# Altium schematic sheet RearPlateMechanicalRev2b.SchDoc
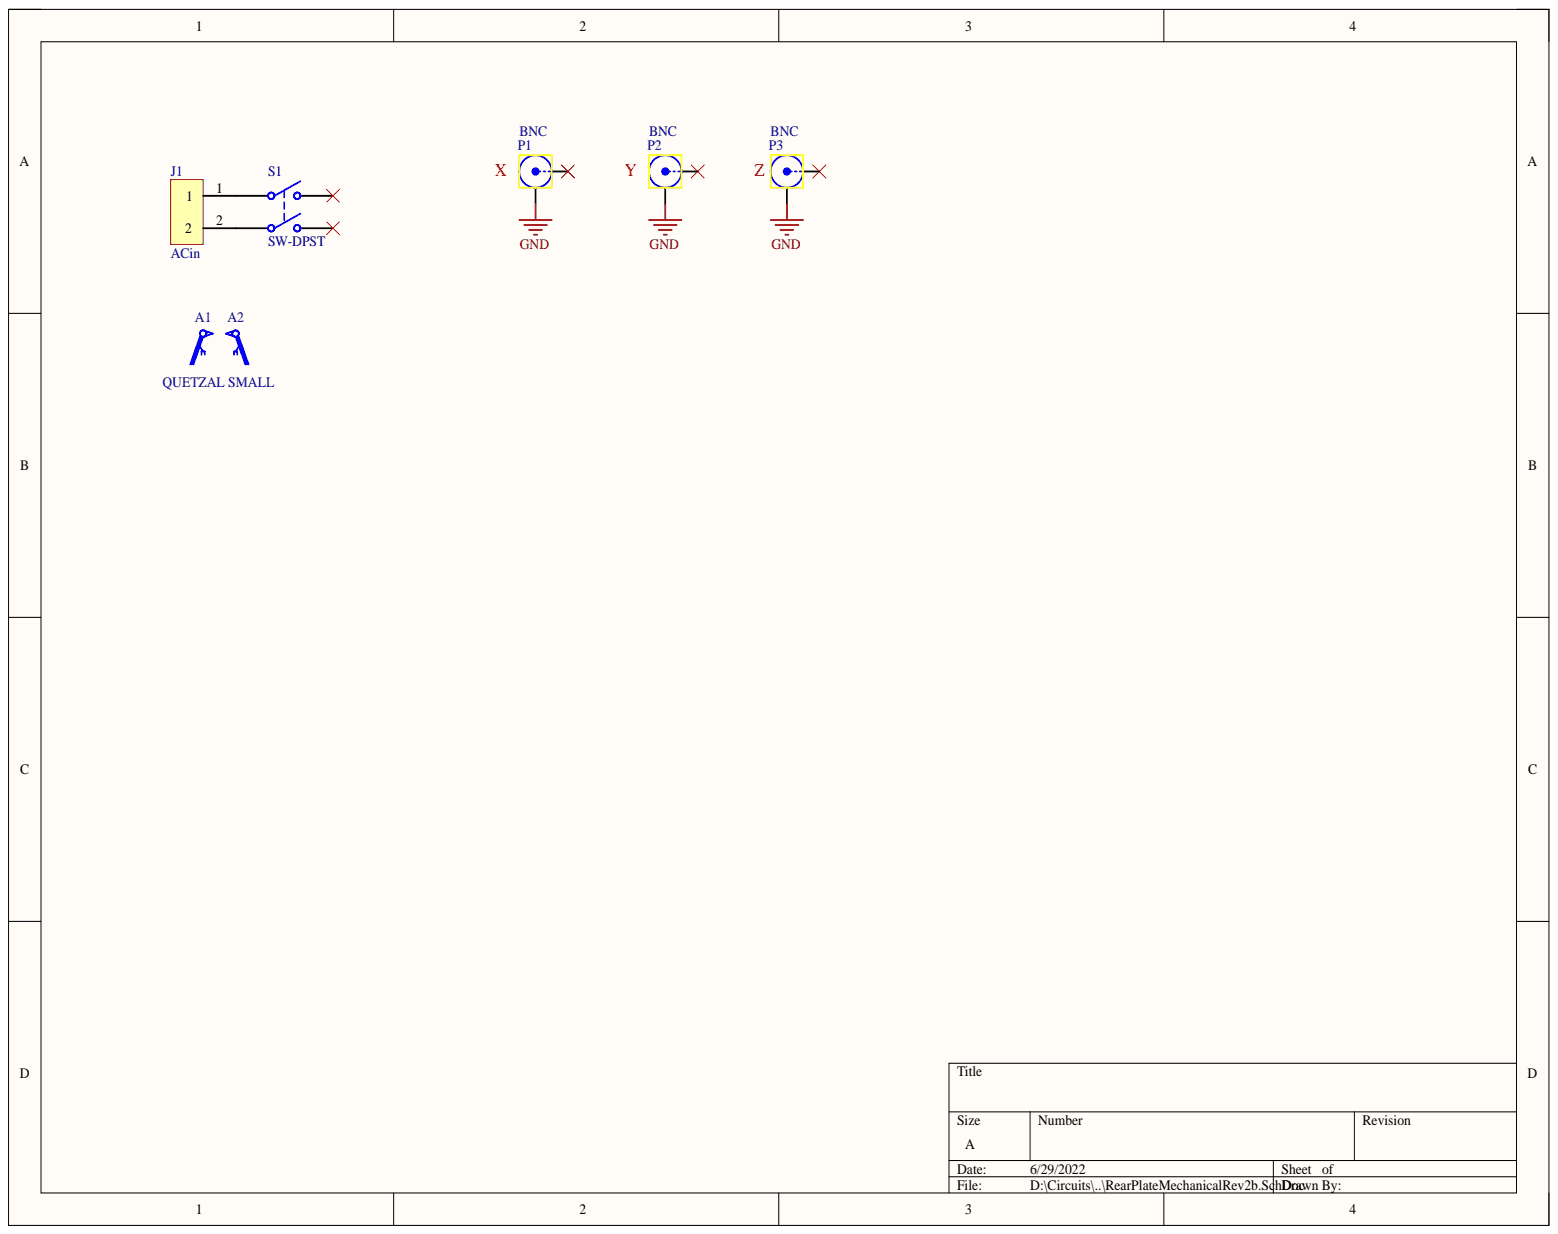
[diagram p0: RearPlateMechanicalRev2b.SchDoc]

## Parts
A1  QUETZAL SMALL  [QUETZAL LT]  (no BOM)
A2  QUETZAL SMALL  [R QUETZAL LT]  (no BOM)
J1  ACin  [5008]
P1  BNC  [BNC]
P2  BNC  [BNC]
P3  BNC  [BNC]
S1  SW-DPST  [CWSB]

## Nets
GND: P1.1 P2.1 P3.1
NetJ1_1: J1.1 S1.1
NetJ1_2: J1.2 S1.3

Not connected: P1 2; P2 2; P3 2; S1 2 4

## Library data of the parts
J1 = 2-Pin: PEM w/Filter, IEC, C8, 250VAC, 2.5A, Front Panel Mt-Screw, Solder Term, Stndrd, IP40, PC2; Manufacturer: Schurter; Manufacturer Part Number: 5008.0022; Approvals: cULus,ENEC; Connector Type: Receptacle; Filter Type: STANDARD; Frequency: 50Hz; Fuse: No; Gender: Male; Max Voltage Rating (AC): 250V; Number of Contacts: 2; Value: 5008; Case/Package: Module; Category: Connectors; Component Kind: Standard; ComponentLink1Description: https://datasheet.ciiva.com/709/eng-cd-640456-w1-709680.pdf?src-supplier=Digi-Key; ComponentLink1URL: https://datasheet.ciiva.com/709/eng-cd-640456-w1-709680.pdf?src-supplier=Digi-Key; ComponentLink2Description: https://datasheet.ciiva.com/3118/eng-ds-82056-mta-0608-3118497.pdf?src-supplier=Avnet; ComponentLink2URL: https://datasheet.ciiva.com/3118/eng-ds-82056-mta-0608-3118497.pdf?src-supplier=Avnet; ComponentLink3Description: https://datasheet.ciiva.com/16401/eng-ds-82056-mta-0608-16401289.pdf?src-supplier=Future+Electronics; ComponentLink3URL: https://datasheet.ciiva.com/16401/eng-ds-82056-mta-0608-16401289.pdf?src-supplier=Future+Electronics; ComponentLink4Description: https://datasheet.ciiva.com/25617/0900766b80027008-25617244.pdf?src-supplier=RSComponents; ComponentLink4URL: https://datasheet.ciiva.com/25617/0900766b80027008-25617244.pdf?src-supplier=RSComponents; ComponentLink5Description: https://datasheet.ciiva.com/14156/04384301-14156820.pdf?src-supplier=Distrelec; ComponentLink5URL: https://datasheet.ciiva.com/14156/04384301-14156820.pdf?src-supplier=Distrelec; ComponentLink6Description: https://datasheet.ciiva.com/11746/0900766b80eb7fdd-11746365.pdf?src-supplier=RSComponents; ComponentLink6URL: https://datasheet.ciiva.com/11746/0900766b80eb7fdd-11746365.pdf?src-supplier=RSComponents; ComponentLink7Description: https://datasheet.ciiva.com/7128/1866390-7128742.pdf?src-supplier=Newark; ComponentLink7URL: https://datasheet.ciiva.com/7128/1866390-7128742.pdf?src-supplier=Newark; ComponentLink8Description: https://datasheet.ciiva.com/16505/eng-ds-82056-mta-0608-16505419.pdf?src-supplier=Avnet+Asia; ComponentLink8URL: https://datasheet.ciiva.com/16505/eng-ds-82056-mta-0608-16505419.pdf?src-supplier=Avnet+Asia; ComponentLink9Description: https://datasheet.ciiva.com/18620/1713017-18620870.pdf?src-supplier=Digi-Key; ComponentLink9URL: https://datasheet.ciiva.com/18620/1713017-18620870.pdf?src-supplier=Digi-Key; ComponentLink10Description: https://datasheet.ciiva.com/16047/1989748-16047438.pdf?src-supplier=Onlinecomponents.com; ComponentLink10URL: https://datasheet.ciiva.com/16047/1989748-16047438.pdf?src-supplier=Onlinecomponents.com; ComponentLink11Description: https://datasheet.ciiva.com/11758/0900766b811d404e-11758587.pdf?src-supplier=RSComponents; ComponentLink11URL: https://datasheet.ciiva.com/11758/0900766b811d404e-11758587.pdf?src-supplier=RSComponents; ComponentLink12Description: https://datasheet.ciiva.com/6746/combiconspring-cagepcbterm-blocks-6746175.pdf?src-supplier=Digi-Key; ComponentLink12URL: https://datasheet.ciiva.com/6746/combiconspring-cagepcbterm-blocks-6746175.pdf?src-supplier=Digi-Key; ComponentLink13Description: https://datasheet.ciiva.com/14607/70055059-14607702.pdf?src-supplier=Allied+Electronics; ComponentLink13URL: https://datasheet.ciiva.com/14607/70055059-14607702.pdf?src-supplier=Allied+Electronics; ComponentLink14Description: https://datasheet.ciiva.com/16051/1988956-16051359.pdf?src-supplier=Onlinecomponents.com; ComponentLink14URL: https://datasheet.ciiva.com/16051/1988956-16051359.pdf?src-supplier=Onlinecomponents.com; ComponentLink15Description: https://datasheet.ciiva.com/26340/567541-da-01-de-printklemme-1988956-250-st-26340451.pdf?src-supplier=Conrad; ComponentLink15URL: https://datasheet.ciiva.com/26340/567541-da-01-de-printklemme-1988956-250-st-26340451.pdf?src-supplier=Conrad; ComponentLink16Description: https://datasheet.ciiva.com/824/combiconcompactseries-824224.pdf?src-supplier=Digi-Key; ComponentLink16URL: https://datasheet.ciiva.com/824/combiconcompactseries-824224.pdf?src-supplier=Digi-Key; ComponentLink17Description: https://datasheet.ciiva.com/14609/70055171-14609100.pdf?src-supplier=Allied+Electronics; ComponentLink17URL: https://datasheet.ciiva.com/14609/70055171-14609100.pdf?src-supplier=Allied+Electronics; ComponentLink18Description: https://datasheet.ciiva.com/30494/0900766b812440a5-30494169.pdf?src-supplier=RSComponents; ComponentLink18URL: https://datasheet.ciiva.com/30494/0900766b812440a5-30494169.pdf?src-supplier=RSComponents; ComponentLink19Description: https://datasheet.ciiva.com/1021/typ-5008-1021352.pdf?src-supplier=Digi-Key; ComponentLink19URL: https://datasheet.ciiva.com/1021/typ-5008-1021352.pdf?src-supplier=Digi-Key; ComponentLink20Description: https://datasheet.ciiva.com/30043/typ-5008-30043239.pdf?src-supplier=Avnet; ComponentLink20URL: https://datasheet.ciiva.com/30043/typ-5008-30043239.pdf?src-supplier=Avnet; ComponentLink21Description: https://datasheet.ciiva.com/14571/70080403-14571658.pdf?src-supplier=Allied+Electronics; ComponentLink21URL: https://datasheet.ciiva.com/14571/70080403-14571658.pdf?src-supplier=Allied+Electronics; Current: 2.5A; Current Rating: 2.5A; Depth: 34.5mm; Flammability Rating: UL94 V-0; Height: 37mm; Housing Material: Thermoplastic; Library Name: MY_CONNECTORS.SchLib; Max Operating Temperature: 85°C; Min Operating Temperature: -25°C; Mount: Panel,Flanges,Screw; Number of Positions: 2; Operating Frequency: 50Hz; Package Quantity: 20; Packaging: Bulk; Pricing 1: 1=5.87000, 50=5.45440 (USD); Ratings: IP40; RoHS: Yes; RoHS Compliant: Yes; Series: 5008; Stock 1: 9; Supplier: Digi-Key; Supplier Part Number: 486-4371-ND; Termination: Solder; Voltage Rating: 250V; Voltage Rating (AC): 250V; Voltage Rating (DC): 250V; Weight: 0.611615oz; Width: 18mm
S1 = SW-DPST: Switch Rocker ON None OFF DPST Quick Connect Curved Rocker 6A 250VAC 30000Cycles; Manufacturer: NKK Switches; Manufacturer Part Number: CWSB21AA1F; Actuator Material: Polycarbonate; Approvals: UL; Electrical Life: 10000Cycles; Illumination Type: Illuminated; Insulation Resistance: 500MR; Max Voltage Rating (AC): 250V; Number of Poles: 2; Switch Function: On-Off; Value: CWSB21AA1F; Actuator Type: Rocker; Body Material: Polycarbonate; Category: Rocker Switches; Color: Black; Component Kind: Standard; ComponentLink1Description: https://datasheet.ciiva.com/2882/cws-2882548.pdf?src-supplier=Digi-Key; ComponentLink1URL: https://datasheet.ciiva.com/2882/cws-2882548.pdf?src-supplier=Digi-Key; ComponentLink2Description: https://datasheet.ciiva.com/28511/1784498-28511005.pdf?src-supplier=Element14; ComponentLink2URL: https://datasheet.ciiva.com/28511/1784498-28511005.pdf?src-supplier=Element14; ComponentLink3Description: https://datasheet.ciiva.com/16289/cws-16289660.pdf?src-supplier=Future+Electronics; ComponentLink3URL: https://datasheet.ciiva.com/16289/cws-16289660.pdf?src-supplier=Future+Electronics; ComponentLink4Description: https://datasheet.ciiva.com/14540/70191982-14540618.pdf?src-supplier=Allied+Electronics; ComponentLink4URL: https://datasheet.ciiva.com/14540/70191982-14540618.pdf?src-supplier=Allied+Electronics; ComponentLink5Description: https://datasheet.ciiva.com/15184/getdatasheetpartid-348129-15184332.pdf?src-supplier=Verical; ComponentLink5URL: https://datasheet.ciiva.com/15184/getdatasheetpartid-348129-15184332.pdf?src-supplier=Verical; Contact Material: Silver; Contact Plating: Silver; Current Rating: 6A; Depth: 15.1mm; Housing Material: Polyamide; Lead Free: Lead Free; Length: 21.1mm; Library Name: My_Miscellaneous Devices.IntLib; Max Current Rating: 6A; Max Operating Temperature: 70°C; Mechanical Life: 30000Cycles; Min Operating Temperature: -10°C; Mount: Panel,Snap in; Number of Positions: 2; Orientation: Vertical; Package Quantity: 1; Packaging: Bulk; Pricing 1: 1=4.64000, 10=4.14000, 25=3.91960, 50=3.77960, 100=3.59960, 250=3.43964, 500=3.13968, 1000=2.99970 (USD); Primary Type: SWITCH; REACH SVHC: No SVHC; RoHS: Yes; Series: CW; Stock 1: 1; Supplier: Digi-Key; Supplier Part Number: 360-1725-ND; Termination: Quick Connect; Throw Configuration: DPST; Voltage Rating (AC): 250V
P1-P3 = BNC: Component Kind: Standard; Library Name: MY_CONNECTORS.SchLib
A1 A2 = QUETZAL SMALL: Library Name: MY_ART.SchLib
